# Altium schematic sheet 08_USB_To_UART.SchDoc
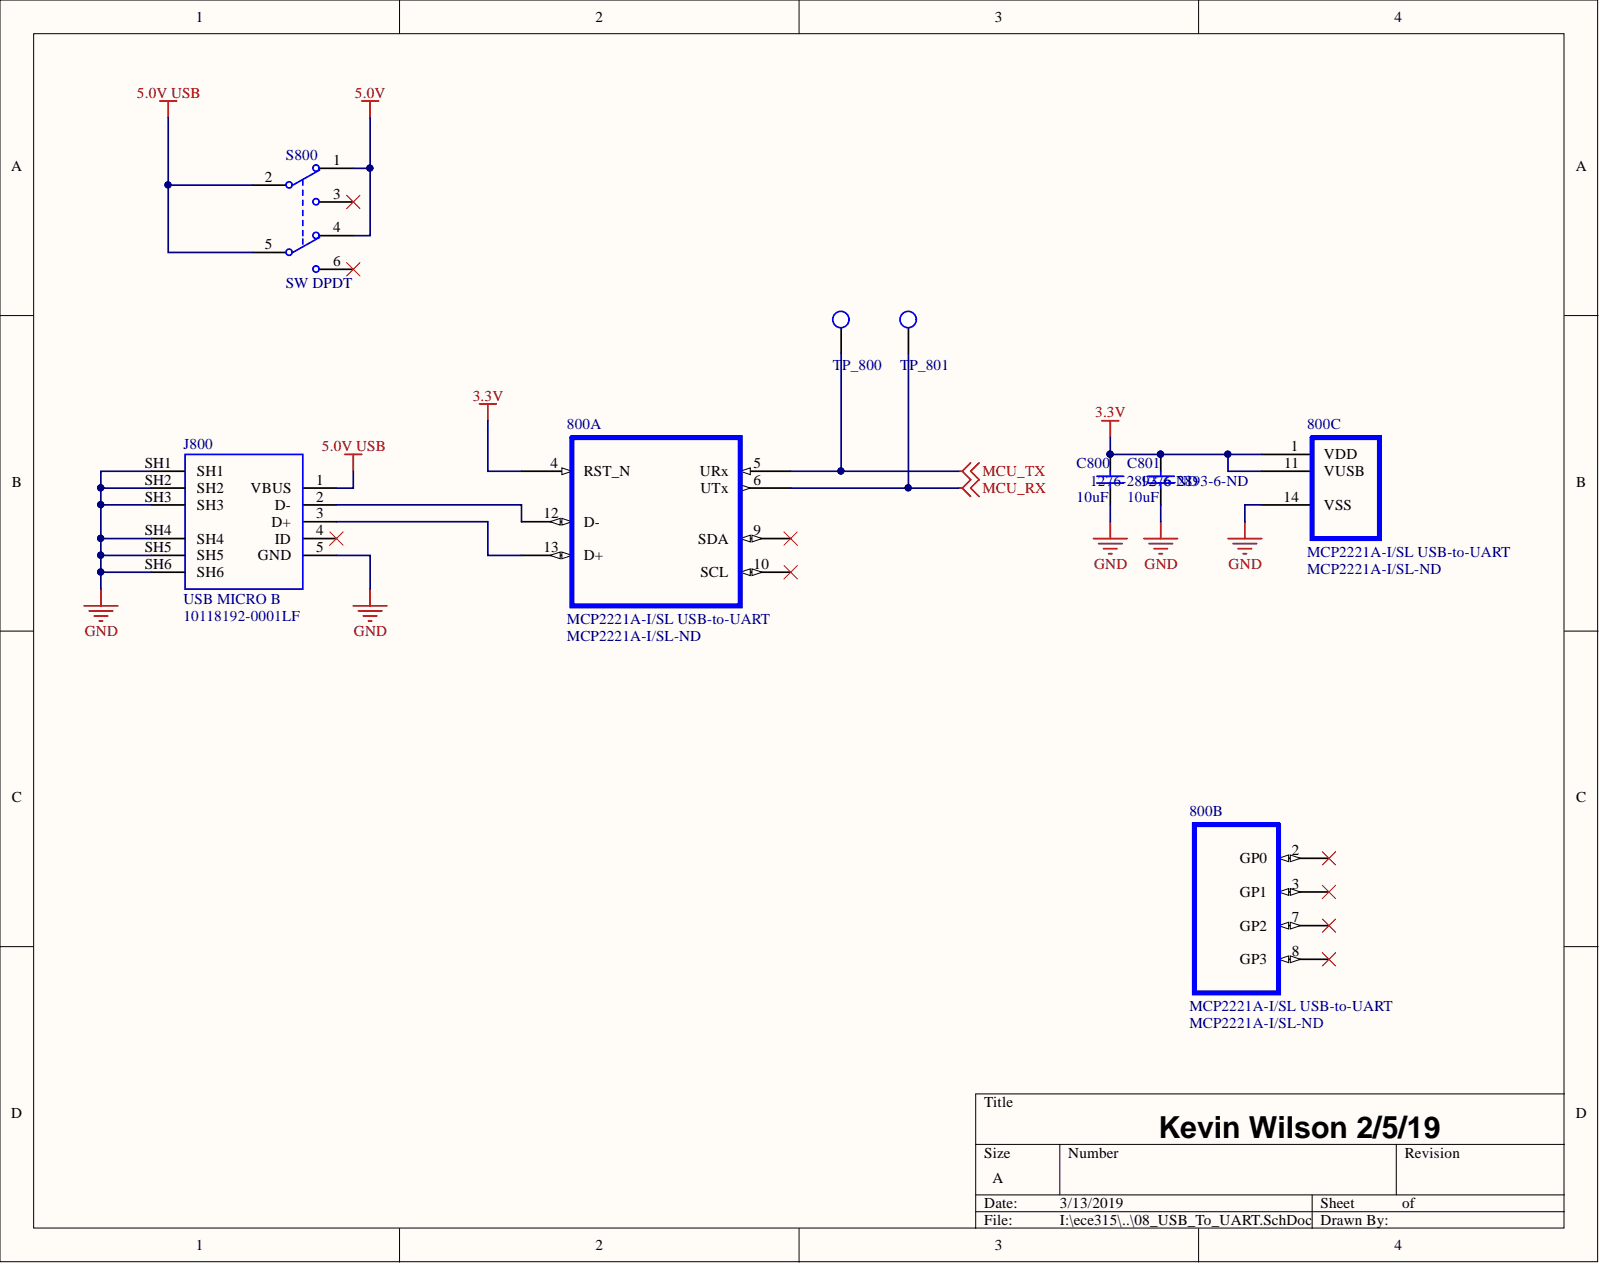
[diagram p0: 08_USB_To_UART.SchDoc]

## Parts
800  MCP2221A-I/SL USB-to-UART  Manufacturer Part Number: MCP2221A-I/SL  Supplier Part Number 1: MCP2221A-I/SL-ND  [IC_ MCP2221]  (parts A,B,C)
C800  10uF  Supplier Part Number 1: 1276-2893-6-ND  [CAP_0805]
C801  10uF  Supplier Part Number 1: 1276-2893-6-ND  [CAP_0805]
J800  value 10118192-0001LF  Tolerance: USB MICRO B  [FCI_10118192]  (hidden comment: CONN_RECP_FCI_10118192-0001LF.1)
S800  SW DPDT  [DPDT]
TP_800  TP_1206  [TP-SMC-1206]  (value not drawn)
TP_801  TP_1206  [TP-SMC-1206]  (value not drawn)

## Nets
3.3V: 800.1(VDD) 800.4(RST_N) 800.11(VUSB) C800.2 C801.2
5.0V: S800.1 S800.4
5.0V USB: J800.1(VBUS) S800.2 S800.5
GND: 800.14(VSS) C800.1 C801.1 J800.5(GND) J800.SH1 J800.SH2 J800.SH3 J800.SH4 J800.SH5 J800.SH6
MCU_RX: 800.6(UTx) TP_801.1
MCU_TX: 800.5(URx) TP_800.1
Net800_12: 800.12(D-) J800.2(D-)
Net800_13: 800.13(D+) J800.3(D+)

Not connected: 800 2(GP0) 3(GP1) 7(GP2) 8(GP3) 9(SDA) 10(SCL); J800 4(ID); S800 3 6

## Library data of the parts
800 = MCP2221A-I/SL USB-to-UART: Manufacturer: Microchip; Interface: I2C,UART; Operating Supply Current: 13mA; Case/Package: SOIC; Component Kind: Standard; Library Name: ece315.SCHLIB; Max Operating Temperature: 85°C; Min Operating Temperature: -40°C; Packaging: Rail/Tube; Pricing 1: 1=1.95, 25=1.6276, 100=1.4832 (USD); Stock 1: 1014; Supplier 1: Digi-Key
C800 C801 = CAP_0805_U_010.00: CAP CER 10UF 16V X5R 0805; Manufacturer: Samsung; Manufacturer Part Number: CL21A106KOFNNNE; Tolerance: 10%; Capacitance: 10uF; Case/Package: 0805; Case Code (Imperial): 0805; Case Code (Metric): 2012; Component Kind: Standard; ComponentLink1Description: https://datasheet.ciiva.com/25730/cl21a106kpclqnc-25730594.pdf; ComponentLink1URL: https://datasheet.ciiva.com/25730/cl21a106kpclqnc-25730594.pdf; ComponentLink2Description: http://www.samsungsem.com/kr/support/product-search/mlcc/CL21A106KOFNNNE.jsp; ComponentLink2URL: http://www.samsungsem.com/kr/support/product-search/mlcc/CL21A106KOFNNNE.jsp; ComponentLink3Description: https://datasheet.ciiva.com/26920/getdatasheetpartid-355170-26920201.pdf; ComponentLink3URL: https://datasheet.ciiva.com/26920/getdatasheetpartid-355170-26920201.pdf; ComponentLink4Description: http://www1.futureelectronics.com/doc/SAMSUNG/CL21A106KOFNNNE.pdf; ComponentLink4URL: http://www1.futureelectronics.com/doc/SAMSUNG/CL21A106KOFNNNE.pdf; ComponentLink5Description: https://www.verical.com/server-webapp/html/getDatasheet?partID=354009; ComponentLink5URL: https://www.verical.com/server-webapp/html/getDatasheet?partID=354009; Depth: 1.25mm; Dielectric: X5R; Height: 1.25mm; Length: 2mm; Library Name: ece315.SCHLIB; Max Operating Temperature: 85°C; Min Operating Temperature: -55°C; Mount: Surface Mount; Package Quantity: 2000; Packaging: Tape and Reel; Pricing 1: 1=0.29, 10=0.199, 100=0.1009, 500=0.06904, 1000=0.06107 (USD); Radiation Hardening: No; Stock 1: 1158169; Supplier 1: Digi-Key; Thickness: 0.053inch; Voltage Rating: 16V; Width: 0.049inch
J800 = CONN_RECP_FCI_10118192-0001LF.1: CONN USB MICRO B RECPT SMT R/A; Component Kind: Standard; Library Name: ece315.SCHLIB; Package: FCI_10118192-0001LF; Supplier 1: Digi-Key; Supplier Part Number 1: 609-4613-1-ND
S800 = SW DPDT: Switch; Manufacturer: ITT /  C&K Components; Manufacturer Part Number: JS202011SCQN; Actuator Color: Black; Actuator Material: Nylon 4/6; Actuator Style: Top Slide; Body Orientation: Straight; Contact Rating @ Voltage: 0.3@6VDC A; COO: US; Dielectric Strength: 500 VAC; Electrical Life: 15000 Cycles; Maximum Contact Resistance: 70 mOhm; Maximum DC Voltage Rating: 6 V; Minimum Insulation Resistance: 100 MOhm; Base Material: N/A; Code_IPC: SOT26A-6; Code_JEITA: SC-74; Component Kind: Standard; ComponentLink1Description: https://datasheet.ciiva.com/23829/1707718-23829488.pdf; ComponentLink1URL: https://datasheet.ciiva.com/23829/1707718-23829488.pdf; ComponentLink2Description: https://datasheet.ciiva.com/2889/js-29aug13-2889127.pdf; ComponentLink2URL: https://datasheet.ciiva.com/2889/js-29aug13-2889127.pdf; ComponentLink3Description: https://datasheet.ciiva.com/2889/js-15sept15-2889128.pdf; ComponentLink3URL: https://datasheet.ciiva.com/2889/js-15sept15-2889128.pdf; ComponentLink4Description: https://datasheet.ciiva.com/2889/js-15sept15-2889129.pdf; ComponentLink4URL: https://datasheet.ciiva.com/2889/js-15sept15-2889129.pdf; ComponentLink5Description: https://datasheet.ciiva.com/22630/1707718-22630697.pdf; ComponentLink5URL: https://datasheet.ciiva.com/22630/1707718-22630697.pdf; ComponentLink6Description: https://datasheet.ciiva.com/8625/js-9dec14-8625470.pdf; ComponentLink6URL: https://datasheet.ciiva.com/8625/js-9dec14-8625470.pdf; Contact Material: Copper Alloy; Contact Plating: Silver; Dimension: 9 x 7.5 x 5.5 mm; ECCN: EAR99; Family: JS; Housing Material: Nylon 4/6; HTS: 8536509050; LatestRevisionDate: 29-May-2009; LatestRevisionNote: IPC-7351 Footprint Added.; Library Name: ece315.SCHLIB; Maximum AC Voltage Rating: N/A; Mechanical Life: N/A; Mounting: Surface Mount,Gull Wing; Operating Temperature: -40 to 85 C; PackageDescription: 6-Pin SOT-23 Package 0.95 mm Pitch, 2.7 mm Lead Span; PackageReference: SOT23-6; PackageVersion: Mar-2001; Packaging: Tape and Reel; Part No: JS202011SCQN; Pole Throw Configuration: DPDT; Product Weight: N/A; Published: 24-Mar-1999; RoHS: Yes; Seal Description: N/A; Seal Location: N/A; Supplier 1: Digi-Key; Supplier Part Number 1: 401-2000-ND; Supplier Standard Pack: 5,000; Switch Configuration: N/A; Type: Sub-Miniature; Publisher: Altium Limited
TP_800 TP_801 = TP_1206: Test Point SMD 1206; Manufacturer: TE Connectivity (Tyco Electronics); Manufacturer Part Number: 1625854-1; Power Rating: N/R; Resistance Value: 0 Ohm; Case Size: 1206; Component Kind: Standard; ComponentLink1Description: https://datasheet.ciiva.com/6439/eng-ds-1773266-a-6439169.pdf; ComponentLink1URL: https://datasheet.ciiva.com/6439/eng-ds-1773266-a-6439169.pdf; ComponentLink2Description: https://datasheet.ciiva.com/30136/1773266-a-30136341.pdf; ComponentLink2URL: https://datasheet.ciiva.com/30136/1773266-a-30136341.pdf; ComponentLink3Description: https://datasheet.ciiva.com/6439/eng-ds-1773266-a-6439080.pdf; ComponentLink3URL: https://datasheet.ciiva.com/6439/eng-ds-1773266-a-6439080.pdf; ComponentLink4Description: https://datasheet.ciiva.com/6439/eng-ds-1773266-a-6439081.pdf; ComponentLink4URL: https://datasheet.ciiva.com/6439/eng-ds-1773266-a-6439081.pdf; ComponentLink5Description: https://datasheet.ciiva.com/6439/eng-ds-1773266-a-6439083.pdf; ComponentLink5URL: https://datasheet.ciiva.com/6439/eng-ds-1773266-a-6439083.pdf; Dimension: 3.2 x 1.6 x 1.25mm; Family: RC; Library Name: ece315.SCHLIB; Mounting: Surface Mount; Operating Temperature: -55 to 125 C; Packaging: Tape and Reel; Part No: 1625854-1; Pricing 1: 1=0.28, 10=0.261, 25=0.224, 50=0.1902, 100=0.1828, 250=0.1641, 500=0.1567, 1000=0.1306 (USD); RoHS: Yes; Stock 1: 31937; Supplier 1: Digi-Key; Supplier Part Number 1: A106143CT-ND; Temperature Coefficient: N/R; Terminal Pitch: N/R; Termination Style: N/R; Tolerance: N/R
